# Altium schematic sheet DoorNode.SchDoc
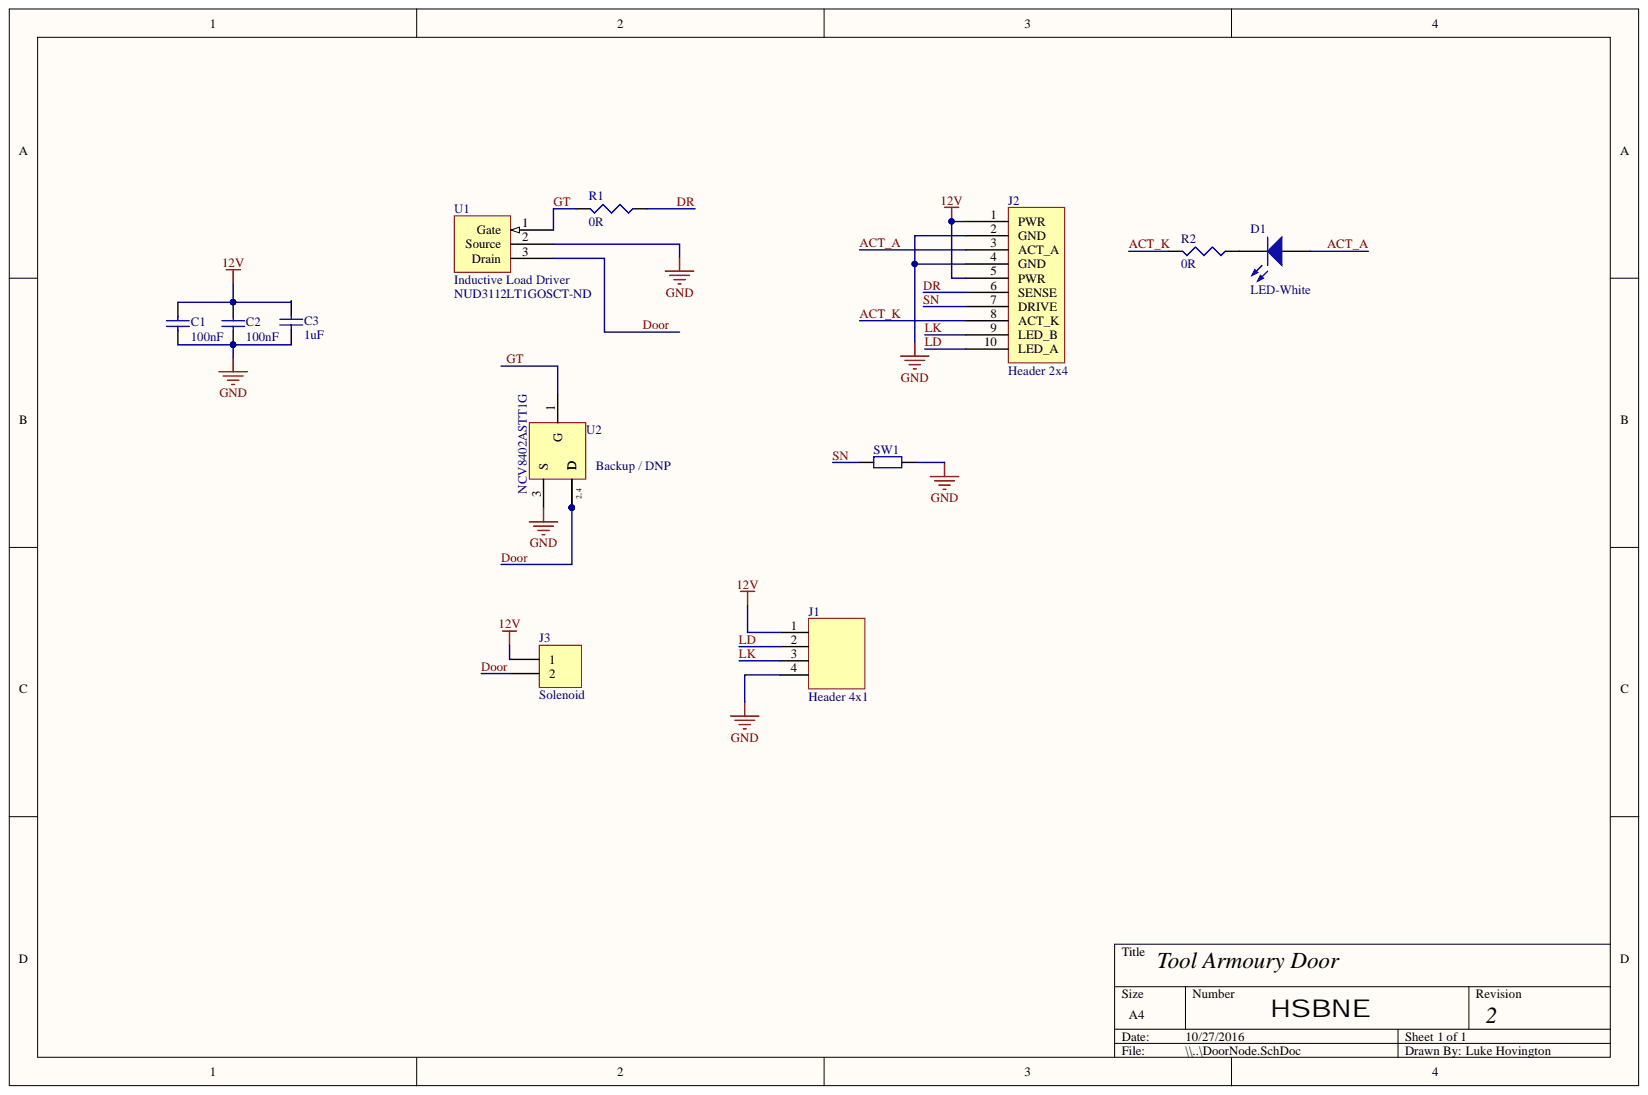
[diagram p0: DoorNode.SchDoc]

## Parts
C1  100nF  [C1206]
C2  100nF  [C1206]
C3  1uF  [C1206]
D1  LED-White  [STW8Q14]
J1  Header 4x1  [4x1]
J2  Header 2x4  [2x5]
J3  Solenoid  [JST-XH]
R1  0R  [C1206]
R2  0R  [C1206]
SW1  Switch  [ReedSwitch]  (value not drawn)
U1  Inductive Load Driver  Supplier Part Number 1: NUD3112LT1GOSCT-ND  [SOT-23]
U2  NCV8402ASTT1G  [ONSC-SOT-223-4-318E-04_V]

## Nets
12V: C1.2 C2.2 C3.2 J1.1 J2.1(PWR) J2.5(PWR) J3.1
GND: C1.1 C2.1 C3.1 J1.4 J2.2(GND) J2.4(GND) SW1.1 U1.2 U2.3(S)
ACT_A: D1.2 J2.3(ACT_A)
ACT_K: J2.8(ACT_K) R2.2
DOOR: J3.2 U1.3 U2.2(D) U2.4
DR: J2.6(SENSE) R1.1
GT: R1.2 U1.1 U2.1(G)
LD: J1.2 J2.10(LED_A)
LK: J1.3 J2.9(LED_B)
SN: J2.7(DRIVE) SW1.2
NetD1_1: D1.1 R2.1

## Library data of the parts
C1 C2 = Cap 100nF: Capacitor (Semiconductor SIM Model); Component Kind: Standard; LatestRevisionDate: 29-May-2009; LatestRevisionNote: IPC-7351 Footprint Added.; PackageDescription: Chip Capacitor; PackageReference: C1206; Published: 8-Jun-2000; Signal Integrity: Cap Semi; Simulation: CAP; Supplier 1: Digi-Key; Supplier 2: Mouser; Supplier Part Number 1: 399-1249-1-ND; Supplier Part Number 2: 80-C1206C104K5R; Value: 100nF; Library Name: ToolDoorController.SCHLIB; Publisher: Altium Limited
C3 = Cap 1uF: Capacitor (Semiconductor SIM Model); Component Kind: Standard; LatestRevisionDate: 29-May-2009; LatestRevisionNote: IPC-7351 Footprint Added.; PackageDescription: Chip Capacitor; PackageReference: C1206; Published: 8-Jun-2000; Signal Integrity: Cap Semi; Simulation: CAP; Supplier 1: Mouser; Supplier 2: Digi-Key; Supplier Part Number 1: 80-C1206C105K5R; Supplier Part Number 2: 399-8150-1-ND; Value: 1uF; Library Name: ToolDoorController.SCHLIB; Publisher: Altium Limited
D1 = LED-White: White; Component Kind: Standard; LatestRevisionDate: 17-Jul-2002; LatestRevisionNote: Re-released for DXP Platform.; PackageDescription: SM LED; 2 Flat Leads; PackageReference: 3.2X1.6X1.1; PackageVersion: Feb-2002; Published: 8-Jun-2000; Simulation: LED2; Supplier 1: Digi-Key; Supplier 2: Digi-Key; Supplier Part Number 1: STW8Q14BE-T0,T5,U0,U7-ET-PCK-ND; Supplier Part Number 2: STW8Q14C-U7V5-DA-SPT-ND; Library Name: DoorNode.SCHLIB; Publisher: Altium Limited
J2 = Header 2x4a: Header; Component Kind: Standard; Library Name: DoorNode.SCHLIB
J3 = Header 2 JST-XH: Header, 2-Pin; Component Kind: Standard; LatestRevisionDate: 17-Jul-2002; LatestRevisionNote: Re-released for DXP Platform.; Signal Integrity: Connector; Supplier 1: Digi-Key; Supplier Part Number 1: 455-2247-ND; Library Name: DoorNode.SCHLIB; Publisher: Altium Limited
R1 R2 = Resistor-10K: Resistor; Value: 10K; Component Kind: Standard; LatestRevisionDate: 29-May-2009; LatestRevisionNote: IPC-7351 Footprint Added.; PackageDescription: Chip Resistor; PackageReference: J1-0603; Published: 8-Jun-2000; Signal Integrity: Res; Simulation: RESISTOR; Supplier 1: Digi-Key; Supplier 2: Mouser; Supplier Part Number 1: P10KECT-ND; Supplier Part Number 2: 71-CRCW1206-10K-E3; Library Name: ToolDoorController.SCHLIB; Publisher: Altium Limited
SW1 = Switch: ReedSwitch; Component Kind: Standard; LatestRevisionDate: 17-Jul-2002; LatestRevisionNote: Re-released for DXP Platform.; PackageDescription: Axial Device, Thru-Hole; 2 Leads; 0.4 in Pin Spacing; PackageReference: AXIAL-0.4; Published: 8-Jun-2000; Signal Integrity: Res; Simulation: RESISTOR; Library Name: DoorNode.SCHLIB; Publisher: Altium Limited
U1 = NUD3112: Integrated Relay,  Inductive Load Driver; Component Kind: Standard; Supplier 1: Digi-Key; Library Name: DoorNode.SCHLIB
U2 = CMP-1069-00019-1: Self-Protected Low Side Driver with Temperature and Current Limit, 4-Pin SOT-223, Pb-Free, Tape and Reel; Manufacturer: On Semiconductor; Channel Polarity: N Channel; Component Kind: Standard; ComponentLink1Description: Manufacturer URL; ComponentLink1URL: http://www.onsemi.com/; ComponentLink2Description: Datasheet; ComponentLink2URL: http://www.onsemi.com/pub_link/Collateral/NCV8402-D.PDF; ComponentLink3Description: Package Specification; ComponentLink3URL: http://www.onsemi.com/pub_link/Collateral/318E-04.PDF; DatasheetVersion: Rev. 8; Library Name: Altium Content Vault; Mounting Technology: Surface Mount; PackageDescription: 4-Pin Small Outline Transistor, Body 6.5 x 3.5 mm, Pitch 2.3 mm; PackageReference: SOT-223-4-318E-04; PackageVersion: Rev. N, 08/2009; Packing: Tape and Reel; PartNumber: NCV8402ASTT1G; RoHS: Pb-Free
J1 = Header 4x1: Component Kind: Standard; Description: Header 4x1; LatestRevisionDate: 17-Jul-2002; LatestRevisionNote: Re-released for DXP Platform.; Signal Integrity: Connector; Library Name: DoorNode.SCHLIB; Publisher: Altium Limited
